# Altium schematic sheet Puls-Oximeter.SchDoc
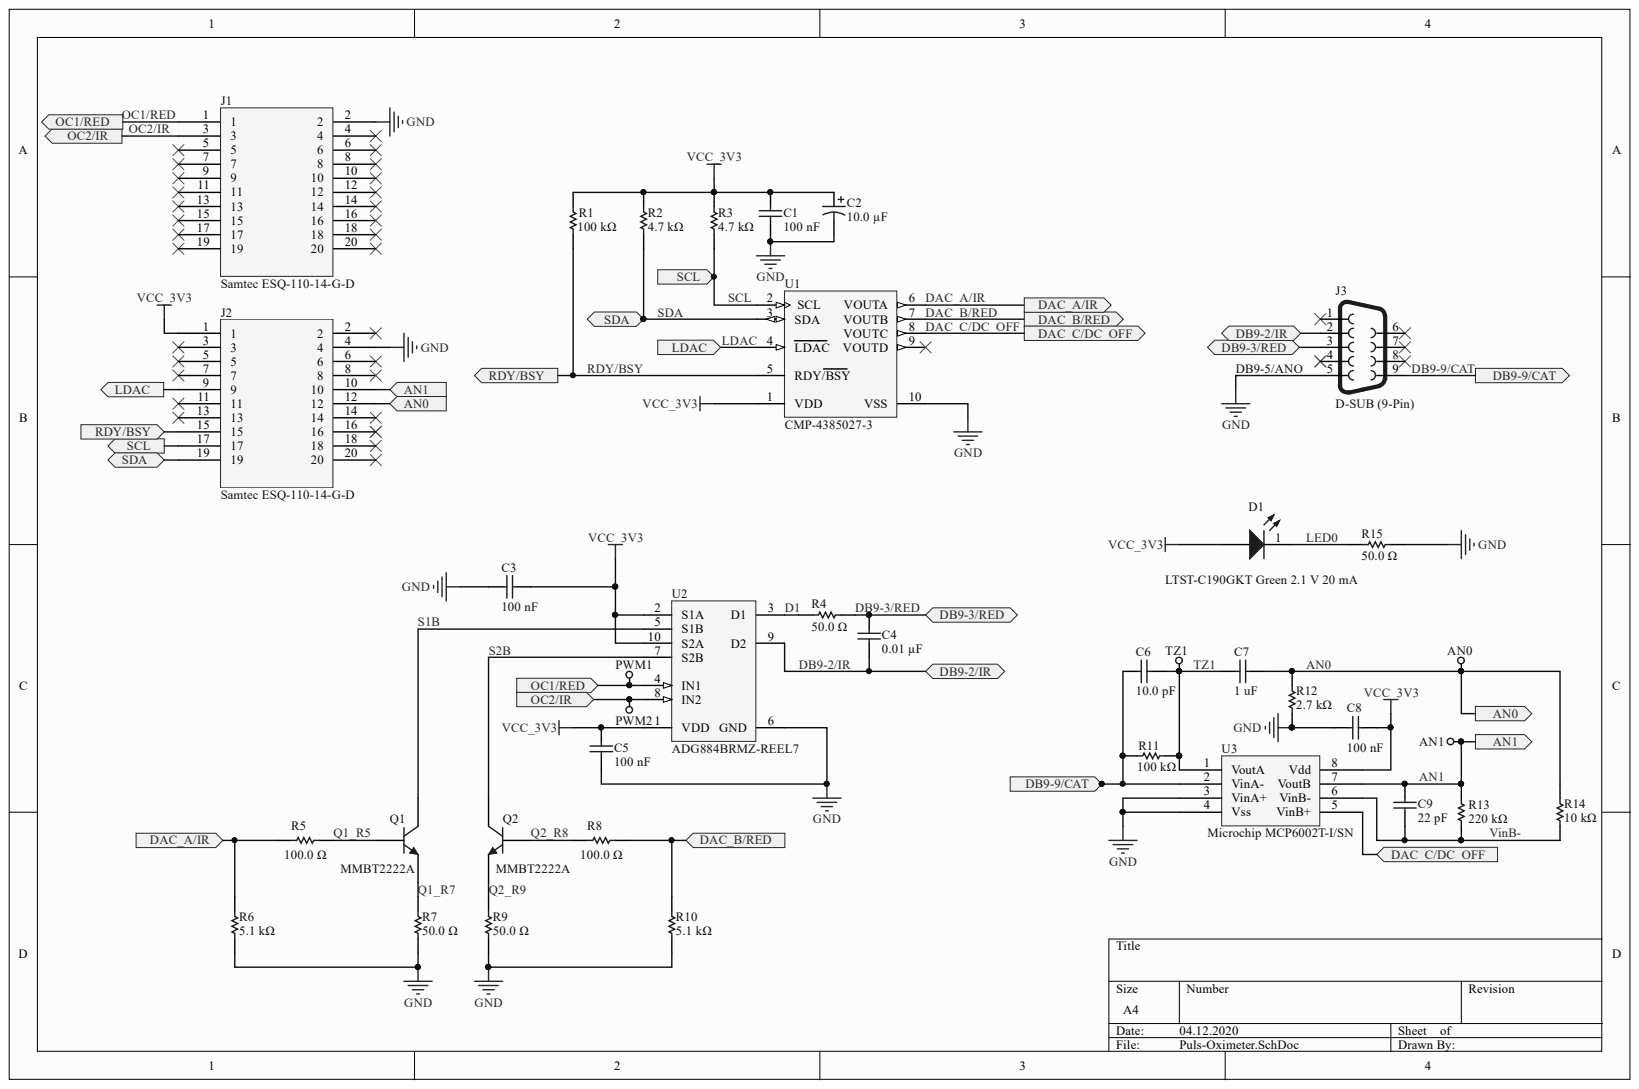
[diagram p0: Puls-Oximeter.SchDoc]

## Parts
AN0  Mill-Max 1508-0-57-15-00-00-03-0  [PCB-k615y7d114z2bwasgswh-1]  (value not drawn)
AN1  Mill-Max 1508-0-57-15-00-00-03-0  [PCB-k615y7d114z2bwasgswh-1]  (value not drawn)
C1  100 nF  [PCB-7rlal0cbwmjoadem8ch0-1]
C2  10.0 µF  [AVX F920J106MPA]
C3  100 nF  [PCB-7rlal0cbwmjoadem8ch0-1]
C4  0.01 µF  [CAPC2013X140X50ML20T25]
C5  100 nF  [PCB-7rlal0cbwmjoadem8ch0-1]
C6  10.0 pF  [PCB-hl84h4ylfsexxgnwkxp4-1]
C7  1 uF  [CAPC2013X145X50NL20T20]
C8  100 nF  [PCB-7rlal0cbwmjoadem8ch0-1]
C9  22 pF  [PCB-p0kkcz0lorojmbp0ovf4-1]
D1  LTST-C190GKT Green 2.1 V 20 mA  Color: Green  Uf: 2.1 V  [DSMD0603GRID25PAD90]
J1  Samtec ESQ-110-14-G-D  [Samtec ESQ-110-14-G-D]
J2  Samtec ESQ-110-14-G-D  [Samtec ESQ-110-14-G-D]
J3  D-SUB (9-Pin)  [PCB-zx3bekuej5w4vut1kj4i-1]
PWM1  Mill-Max 1508-0-57-15-00-00-03-0  [PCB-k615y7d114z2bwasgswh-1]  (value not drawn)
PWM2  Mill-Max 1508-0-57-15-00-00-03-0  [PCB-k615y7d114z2bwasgswh-1]  (value not drawn)
Q1  MMBT2222A  [SOT23-3]
Q2  MMBT2222A  [SOT23-3]
R1  100 kΩ  [PCB-k45wvucfpmu9qpt2nel3-1]
R2  4.7 kΩ  [RESC2013X50X30NL20T20]
R3  4.7 kΩ  [RESC2013X50X30NL20T20]
R4  50.0 Ω  [SMD resistor]
R5  100.0 Ω  [RESC2013X50X30NL20T20]
R6  5.1 kΩ  [RESC2013X50X30NL20T20]
R7  50.0 Ω  [SMD resistor]
R8  100.0 Ω  [RESC2013X50X30NL20T20]
R9  50.0 Ω  [SMD resistor]
R10  5.1 kΩ  [RESC2013X50X30NL20T20]
R11  100 kΩ  [PCB-k45wvucfpmu9qpt2nel3-1]
R12  2.7 kΩ  [RESC2013X50X30NL20T20]
R13  220 kΩ  [RESC2013X50X30NL20T20]
R14  10 kΩ  [PCB-985f43qkinkfszo44vce-1]
R15  50.0 Ω  [SMD resistor]
TZ1  Mill-Max 1508-0-57-15-00-00-03-0  [PCB-k615y7d114z2bwasgswh-1]  (value not drawn)
U1  CMP-4385027-3  [MSOP-UN10_M]
U2  ADG884BRMZ-REEL7  [ADI-RM-10_L]
U3  Microchip MCP6002T-I/SN  [PCBComponent_1]

## Ports
AN0, AN1, DAC_A/IR, DAC_B/RED, DAC_C/DC_OFF, DB9-2/IR, DB9-3/RED, DB9-9/CAT, LDAC, OC1/RED, OC2/IR, RDY/BSY, SCL, SDA

## Nets
GND: C1.2 C2.2 C3.2 C5.2 C8.1 J1.2 J2.4 J3.5 R6.1 R7.1 R9.1 R10.1 R12.1 R15.2 U1.10(VSS) U2.6(GND) U3.3(VinA+) U3.4(Vss)
VCC_3V3: C1.1 C2.1 C3.1 C5.1 C8.2 D1.2 J2.1 R1.2 R2.2 R3.2 U1.1(VDD) U2.1(VDD) U2.2(S1A) U2.10(S2A) U3.8(Vdd)
AN0: AN0.1 C7.1 J2.12 R12.2 R14.2 ; port AN0
AN1: AN1.1 C9.2 J2.10 R13.2 U3.7(VoutB) ; port AN1
D1: R4.1 U2.3(D1)
DAC_A/IR: R5.1 R6.2 U1.6(VOUTA) ; port DAC_A/IR
DAC_B/RED: R8.2 R10.2 U1.7(VOUTB) ; port DAC_B/RED
DAC_C/DC_OFF: U1.8(VOUTC) U3.5(VinB+) ; port DAC_C/DC_OFF
DB9-2/IR: C4.1 J3.2 U2.9(D2) ; port DB9-2/IR
DB9-3/RED: C4.2 J3.3 R4.2 ; port DB9-3/RED
DB9-9/CAT: C6.1 J3.9 R11.1 U3.2(VinA-) ; port DB9-9/CAT
LDAC: J2.9 U1.4(LDAC) ; port LDAC
LED0: D1.1 R15.1
OC1/RED: J1.1 PWM1.1 U2.4(IN1) ; port OC1/RED
OC2/IR: J1.3 PWM2.1 U2.8(IN2) ; port OC2/IR
Q1_R5: Q1.1 R5.2
Q1_R7: Q1.2 R7.2
Q2_R8: Q2.1 R8.1
Q2_R9: Q2.2 R9.2
RDY/BSY: J2.15 R1.1 U1.5(RDY/BSY) ; port RDY/BSY
S1B: Q1.3 U2.5(S1B)
S2B: Q2.3 U2.7(S2B)
SCL: J2.17 R3.1 U1.2(SCL) ; port SCL
SDA: J2.19 R2.1 U1.3(SDA) ; port SDA
TZ1: C6.2 C7.2 R11.2 TZ1.1 U3.1(VoutA)
VINB-: C9.1 R13.1 R14.1 U3.6(VinB-)

Not connected: J1 4 5 6 7 8 9 10 11 12 13 14 15 16 17 18 19 20; J2 2 3 5 6 7 8 11 13 14 16 18 20; J3 1 4 6 7 8; U1 9(VOUTD)

## Library data of the parts
AN0 AN1 PWM1 PWM2 TZ1 = CMP-954155-14: Tilt Switch; Beam Angle: 15°; Output Current: 1A; Contact Current Max: 1A; Contact Resistance Max: 0.08ohm; Leaded...; Manufacturer Part Number: 5002; Lead-Free Status: Lead Free; Output Current: 1.00 A; Color: White; Component Kind: Standard; Contact Material: Phosphor Bronze; Contact Plating: Tin, Silver; Library Name: CommunityVault; Octopart URL: https://octopart.com/5002-keystone-5193; Packaging: Bag; REACH SVHC Compliance: No SVHC; RoHS: Compliant; Size-Diameter: 2.54 mm; Size-Height: 7.62 mm; Size-Inner Diameter: 1.27 mm; Size-Length: 7.62 mm
C1 C3 C5 C8 = CMP-7da2b1f9736fa265-2: In a Pack of 150, Wurth Elektronik 0805 (2012M) MLCC 885012207128; Capacitance Tolerance: ±10%; Dielectric Characteristic: X7R; Voltage Rating (DC): 100 V; Capacitance: 100 nF; Component Kind: Standard; Library Name: CommunityVault; Manufacturer Part Number: 885012207128; Octopart URL: https://octopart.com/885012207128-w%C3%BCrth+elektronik-89205675; RoHS: Compliant
C2 = CMP-78d3b07d355ca714-4: Cap Tant Solid 10uF 6.3V P CASE 20% (2 X 1.25 X 1.1mm) Inward L SMD 6 Ohm 125C T/R; Manufacturer Part Number: F920J106MPA; Equivalent Series Resistance (ESR): 6.00 Ω; Lead-Free Status: Lead Free; Voltage Rating (DC): 6.30 V; Capacitance: 10.0 µF; Capacitance Tolerance: ±20%; Case/Package: 2012, 0805; Component Kind: Standard; Library Name: CommunityVault; Mounting Style: Surface Mount; Number of Pins: 2; OctopartID: 78d3b07d355ca714; Octopart URL: https://octopart.com/f920j106mpa-avx-27551977; Operating Temperature: -55.0 °C (min); Packaging: Cut Tape (CT); RoHS: Compliant; Size-Height: 1.20 mm; Size-Length: 2.00 mm; Size-Width: 1.25 mm
C4 = CMP-126079-7: 0805 Cap Ceramic; Manufacturer Part Number: 08051C103KAT2A; Capacitance Tolerance: ±10%; Case/Package (SI): 2012; Dielectric Characteristic: X7R; Dielectric Material: Ceramic Multilayer; Insulation Resistance: 1e+11 Ω; Voltage Rating (DC): 100.0 V; Capacitance: 0.01 µF; Case/Package: 0805; Component Kind: Standard; Library Name: CommunityVault; Mounting Style: Surface Mount; Number of Pins: 2; OctopartID: 6e45a58aebfbb182; Octopart Url: https://octopart.com/08051c103kat2a-avx+interconnect+%2F+elco-46506200; REACH SVHC Compliance: No SVHC; RoHS: Compliant; Size-Height: 0.00094 m; Size-Length: 0.0020066 m; Size-Thickness: 0.0009398 m; Size-Width: 0.0012446 m
C6 = CMP-7ba4b7ff75aba463-2: Cap, Mlcc, C0g, 10Pf, 100V, 0805; Manufacturer Part Number: 885012007076; Capacitance Tolerance: ±5%; Dielectric Characteristic: C0G/NP0; Capacitance: 10.0 pF; Component Kind: Standard; Library Name: CommunityVault; OctopartID: 7ba4b7ff75aba463; Octopart URL: https://octopart.com/885012007076-w%C3%BCrth+elektronik-89205609; Operating Temperature: -55.0 °C (min); RoHS: Compliant; Value: 10pF
C7 = CMP-3553356-2: Multilayer Ceramic Capacitors MLCC - SMD/SMT 0805 1uF 10volts X7R 10%; Manufacturer Part Number: VJ0805Y105KXQRW1BC; Dielectric Material: Tantalum; Lead-Free Status: Lead Free; Voltage Rating (DC): 10.0 V; Capacitance: 1 uF; Capacitance Tolerance: ±10%; Case/Package: 0805; Component Kind: Standard; Dielectric Characteristic: X7R; Library Name: CommunityVault; OctopartID: 74f2bf708f9dab35; Octopart Url: https://octopart.com/vj0805y105kxqrw1bc-vishay+vitramon-42524169; RoHS: Compliant
C9 = CMP-40868a6a5cbc9f41-2: Multilayer Ceramic Capacitor 22PF 0805 5; Voltage Rating (DC): 25.0 V; Capacitance: 22 pF; Component Kind: Standard; Library Name: CommunityVault
D1 = CMP-1544853-18: Green 1.6 x 0.8 x 0.8 mm 130° Water Clear 6 mcd 2.1 V Surface Mount LED; If: 20 mA; Modell: Lite-On LTST-C190GKT; Uin: 3.3 V; Component Kind: Standard; Library Name: CommunityVault
J1 J2 = CMP-e6582ff0f342399f-1: Conn Elevated Socket SKT 20 POS 2.54mm Solder ST Top Entry Thru-Hole Tube; Component Kind: Standard; Library Name: CommunityVault; RoHS: Compliant
J3 = CMP-b29b79fcf2646d5c-5: D-Subminiature Connectors, Connectors, D-Subminiature and Accessories, Connectors and Adapters; Component Kind: Standard; Library Name: CommunityVault
Q1 Q2 = CMP-4e66842144c991a1-1: MMBT2222 Series 40 V CE Breakdown 1 A NPN General Purpose Amplifier - SOT-23; Breakdown Voltage [Collector to Emitter]: 40.0 V; Current Rating: 500 mA; Lead-Free Status: Lead Free; Power Dissipation: 350 mW; Case/Package: SOT-23; Component Kind: Standard; Library Name: CommunityVault; Lifecycle Status: Not Listed by Manufacturer; Manufacturer Part Number: MMBT2222A; Mounting Style: Surface Mount; Number of Pins: 3; OctopartID: 4e66842144c991a1; Octopart Url: http://octopart.com/mmbt2222a-fairchild+semiconductor-55390153; Packaging: Cut Tape (CT); Polarity: NPN; REACH SVHC Compliance: No SVHC; RoHS: Compliant; Seller Landing  Url: https://octopart-clicks.com/click/track?country=US&ak=8157f166&sig=002a1c0&sid=459&ppid=55390153&vpid=188020359&ct=offers; Voltage Rating (DC): 40.0 V
R1 R11 = CMP-67deafbf61b9b819-1: Res Thick Film 0805 100K Ohm 1% 1/8W ±100ppm/°C Molded SMD Paper T/R; Manufacturer Part Number: CRCW0805100KFKEAC; Lead-Free Status: Lead Free; Component Kind: Standard; Library Name: CommunityVault; OctopartID: 67deafbf61b9b819; Octopart URL: https://octopart.com/crcw0805100kfkeac-vishay-23798529; Resistance: 100 kΩ; RoHS: Compliant
R2 R3 = CMP-3655922-2: Thick Film Resistors - SMD 1/8watt 4.7Kohms 5%; Manufacturer Part Number: CRCW08054K70JNEB; Lead-Free Status: Lead Free; Power Rating: 0.125 W; Voltage Rating (DC): 150.0 V; Case/Package: 0805; Component Kind: Standard; Library Name: CommunityVault; Number of Pins: 2; OctopartID: 92dd59a6452b4d1a; Octopart Url: https://octopart.com/crcw08054k70jneb-vishay+dale-41022096; Packaging: Tape & Reel (TR); Resistance: 4.7 kΩ; Resistance Tolerance: ±5%; RoHS: Compliant
R4 R7 R9 R15 = CMP-c5c70d8b84031a00-1: Res Thick Film 0805 50 Ohm 1% 1/8W ±100ppm/°C Molded SMD Paper T/R; Manufacturer Part Number: CRCW080550R0FKTA; Lead-Free Status: Contains Lead; Power Rating: 125 mW; Case/Package: 0805; Component Kind: Standard; Library Name: CommunityVault; OctopartID: c5c70d8b84031a00; Packaging: Reel; Resistance: 50.0 Ω; Resistance Tolerance: ±1%; RoHS: Non-Compliant
R5 R8 = CMP-1867491-2: RES SMD 100 OHM 1% 1/8W 0805; Manufacturer Part Number: CRCW0805100RFKEA; Case/Package (SI): 2012; Lead-Free Status: Lead Free; Power Rating: 0.125 W; Temperature Coefficient: 100.0 ppm/°C; Voltage Rating (DC): 150.0 V; Case/Package: 0805; Component Kind: Standard; Library Name: CommunityVault; Mounting Style: Surface Mount; Number of Pins: 2; OctopartID: 7f31b4fef98da0f6; Octopart Url: https://octopart.com/crcw0805100rfkea-vishay-46506970; REACH SVHC Compliance: No SVHC; Resistance: 100.0 Ω; Resistance Tolerance: ±1%; RoHS: Compliant; Size-Height: 0.00045 m; Size-Length: 0.002 m; Size-Width: 0.00125 m
R6 R10 = CMP-1877658-2: RES SMD 5.1K OHM 1% 1/8W 0805; Manufacturer Part Number: CRCW08055K10FKEA; Case/Package (SI): 2012; Lead-Free Status: Lead Free; Power Rating: 0.125 W; Voltage Rating (DC): 150.0 V; Case/Package: 0805; Component Kind: Standard; Library Name: CommunityVault; Mounting Style: Surface Mount; Number of Pins: 2; OctopartID: 0b48c01da8e1d48f; Octopart Url: https://octopart.com/crcw08055k10fkea-vishay-39814863; REACH SVHC Compliance: No SVHC; Resistance: 5.1 kΩ; Resistance Tolerance: ±1%; RoHS: Compliant; Size-Height: 0.00045 m; Size-Length: 0.002 m; Size-Width: 0.00125 m
R12 = CMP-1856766-2: RES SMD 2.7K OHM 5% 1/8W 0805; Manufacturer Part Number: CRCW08052K70JNEA; Case/Package (SI): 2012; Lead-Free Status: Lead Free; Power Rating: 0.125 W; Temperature Coefficient: 200.0 ppm/°C; Voltage Rating (DC): 150.0 V; Case/Package: 0805; Component Kind: Standard; Library Name: CommunityVault; Mounting Style: Surface Mount; Number of Pins: 2; OctopartID: 0145ca152f18de82; Octopart Url: https://octopart.com/crcw08052k70jnea-vishay-39455547; Resistance: 2.7 kΩ; Resistance Tolerance: ±5%; RoHS: Compliant; Size-Height: 0.00045 m; Size-Length: 0.002 m; Size-Width: 0.00125 m
R13 = CMP-1877691-2: RES SMD 220K OHM 1% 1/8W 0805; Manufacturer Part Number: CRCW0805220KFKEA; Case/Package (SI): 2012; Lead-Free Status: Lead Free; Power Rating: 0.125 W; Temperature Coefficient: 100.0 ppm/°C; Voltage Rating (DC): 150.0 V; Case/Package: 0805; Component Kind: Standard; Library Name: CommunityVault; Mounting Style: Surface Mount; Number of Pins: 2; OctopartID: 96f75da2e3354930; Octopart Url: https://octopart.com/crcw0805220kfkea-vishay-39800996; REACH SVHC Compliance: No SVHC; Resistance: 220 kΩ; Resistance Tolerance: ±1%; RoHS: Compliant; Size-Height: 0.00045 m; Size-Length: 0.002 m; Size-Width: 0.00125 m
R14 = CMP-fbb033d1fdd32477-3: Res Thick Film 0805 10K Ohm 1% 1/8W ±100ppm/°C Molded SMD Paper T/R; Model: CRCW080510K0FKEAC; Component Kind: Standard; Library Name: CommunityVault; Resistance: 10 kΩ
U1 = CMP-4385027-3: 12-Bit Quad Digital-to-Analog Converter with EEPROM Memory, 10-pin MSOP, Extended Temperature, Tape and Reel; Manufacturer Part Number: MCP4728T-E/UN; Lead-Free Status: Lead Free; Component Kind: Standard; Library Name: CommunityVault; Lifecycle Status: Active; OctopartID: 557f9d7984fe8ab8; Octopart Url: https://octopart.com/mcp4728t-e%2Fun-microchip-17626343; RoHS: Compliant
U2 = ADG884BRMZ-REEL7: 0.5 Ohm CMOS, Dual 2:1 MUX / SPDT Audio Switches, -40 to 85 degC, 10-pin SOP (RM-10), Reel; Lead-Free Status: Contains Lead; Number of Bits: 2; Power Dissipation: 5.5e-06 W; Case/Package: MSOP; Component Kind: Standard; Contacts Type: SPDT; Library Name: Octopart library; Lifecycle Status: Active; Manufacturer Part Number: ADG884BRMZ-REEL7; Mounting Style: Surface Mount; Number of Pins: 10; OctopartID: 43af8aa2b2129c68; Octopart Url: https://octopart.com/adg884brmz-reel7-analog+devices-513243; RoHS: Compliant
U3 = MCP6002T-I/SN: Op Amp Dual Low Power Amplifier R-R I/O 6V Automotive 8-Pin SOIC N T/R; Gain Bandwidth Product: 1.00 MHz; Supply Current: 170 µA (max); Component Kind: Standard; Library Name: Octopart library
